# Altium schematic sheet MLRS_E28_TX.SchDoc
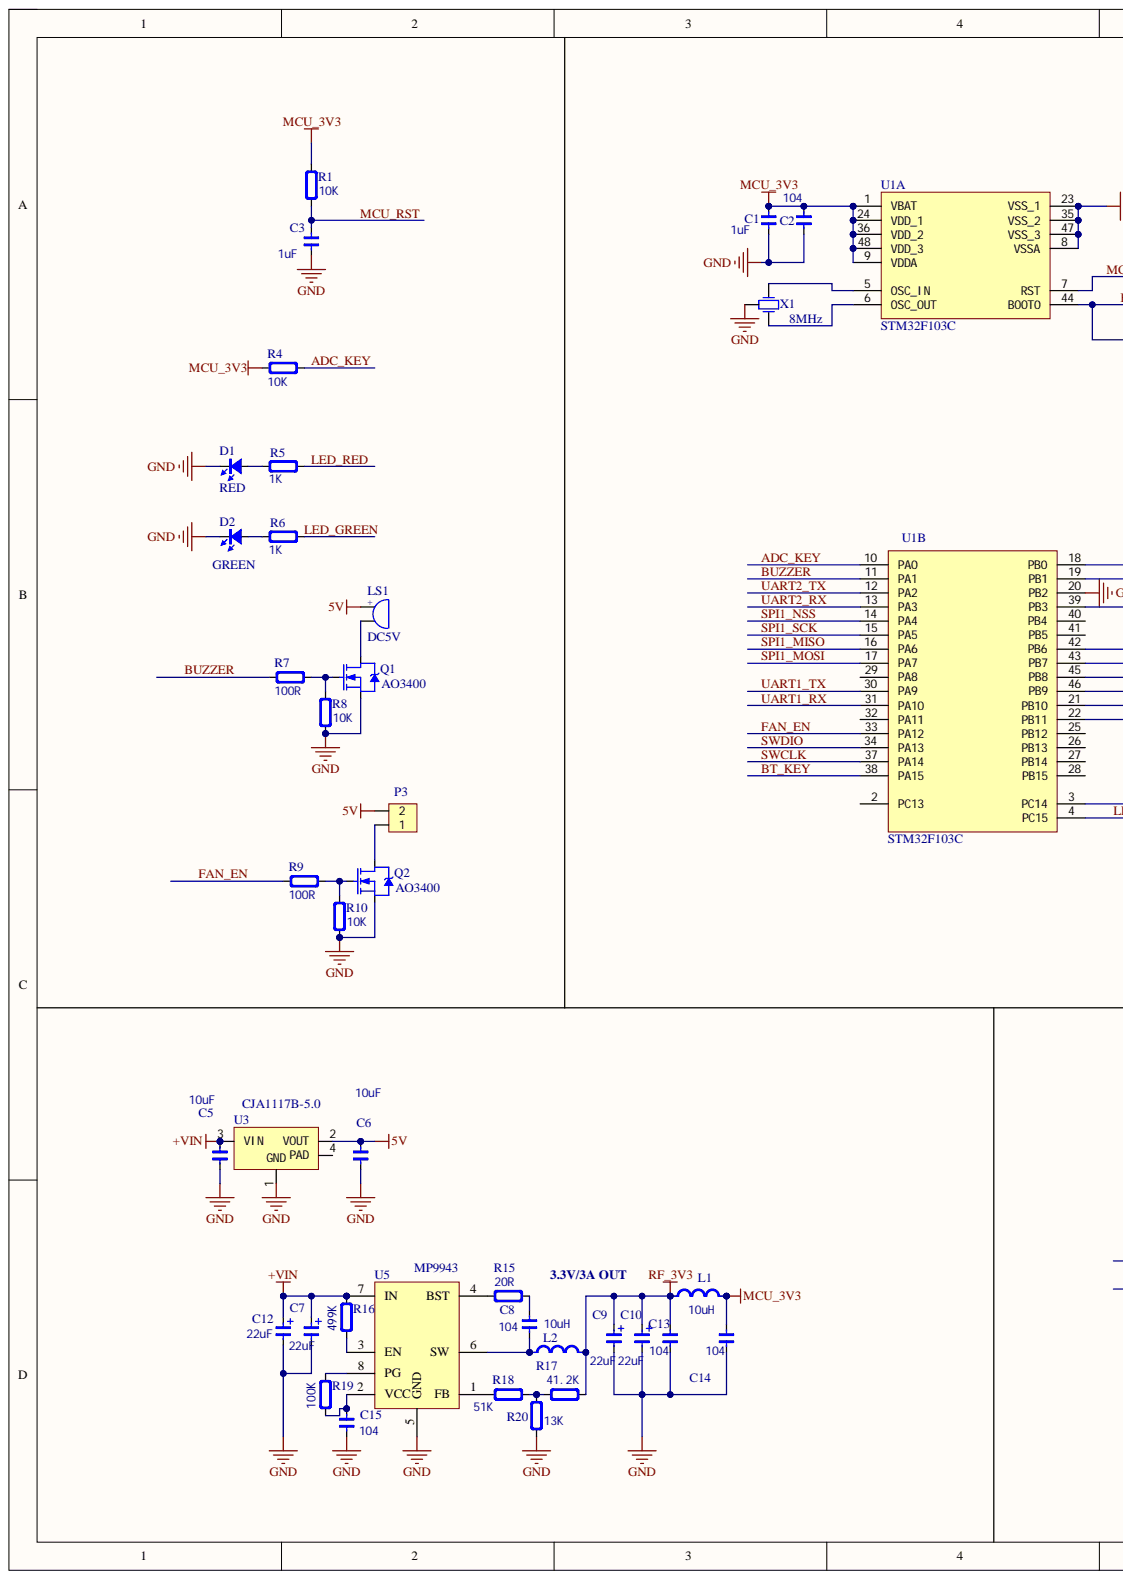
[diagram p0: MLRS_E28_TX.SchDoc, left]
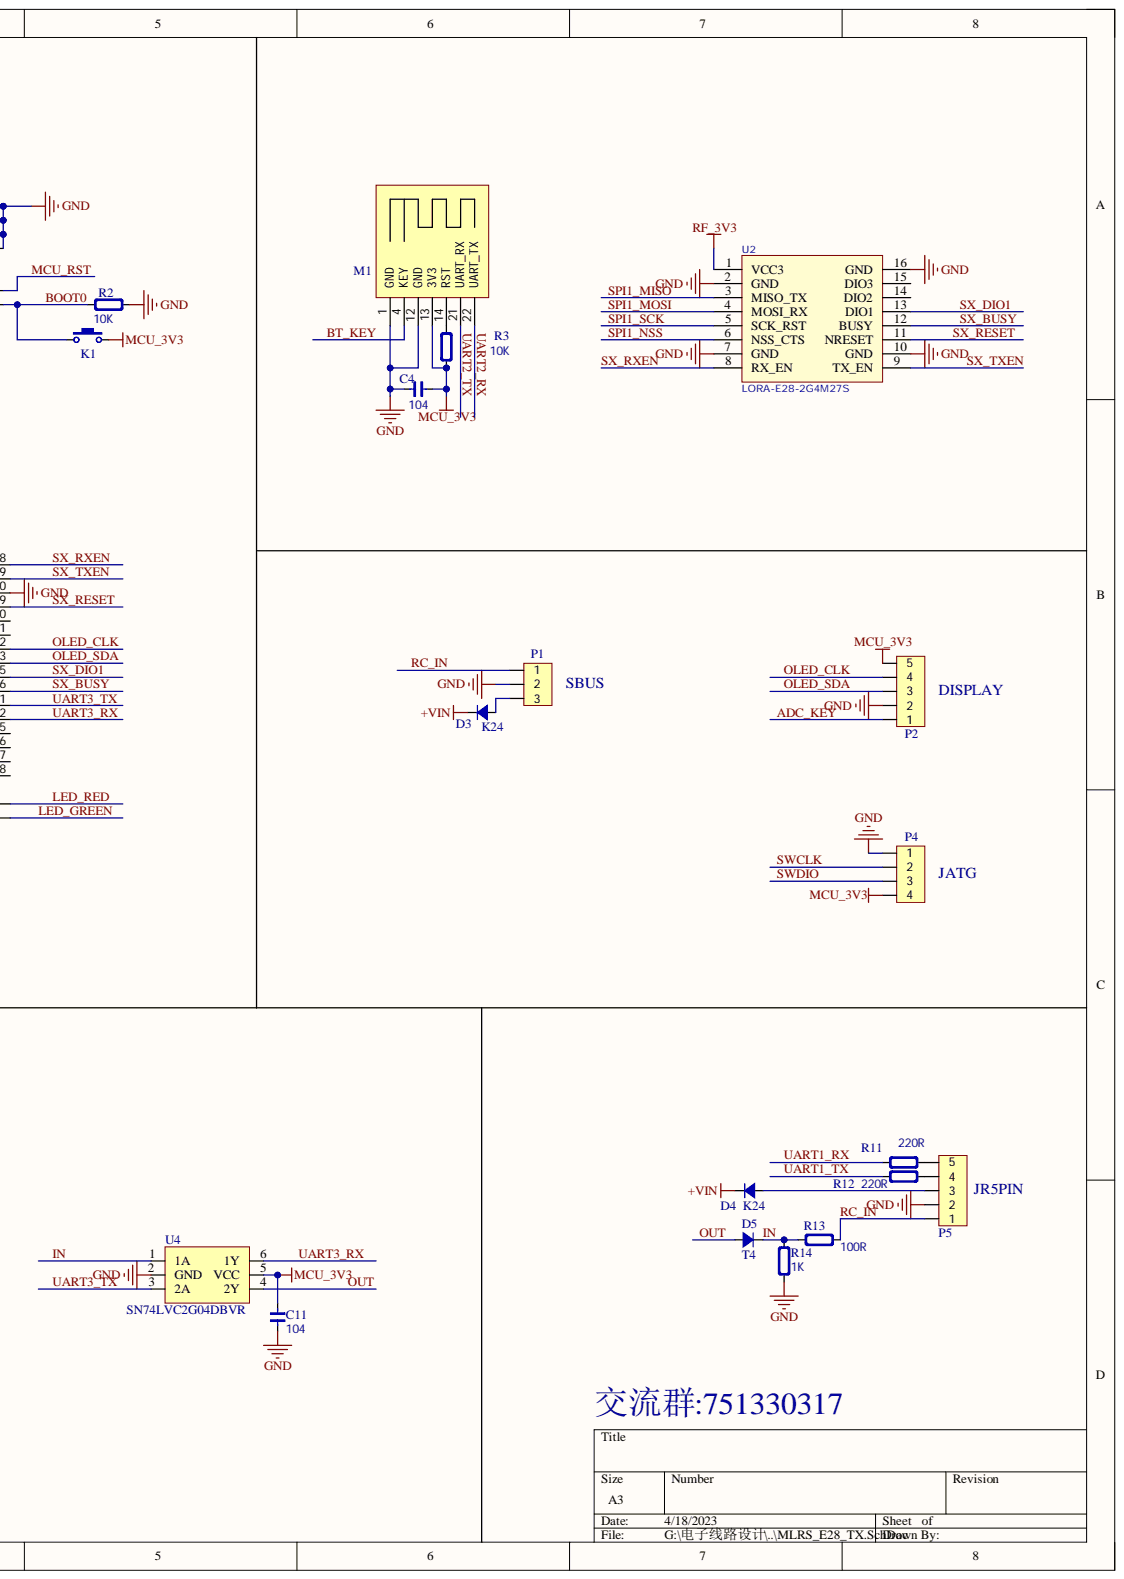
[diagram p1: MLRS_E28_TX.SchDoc, right]

## Parts
C1  1uF  [C0402S]
C2  104  [C0402S]
C3  1uF  [C0402S]
C4  104  [C0402S]
C5  10uF  [CAP_0603]
C6  10uF  [CAP_0603]
C7  22uF  [CAP_TAN_A1206]
C8  104  [C0402S]
C9  22uF  [CAP_TAN_A1206]
C10  22uF  [CAP_TAN_A1206]
C11  104  [C0402S]
C12  22uF  [CAP_TAN_A1206]
C13  104  [CAP_0603]
C14  104  [CAP_0603]
C15  104  [C0402S]
D1  RED  [LED_0603_RED]
D2  GREEN  [LED_0603_GREEN]
D3  K24  [SOD-123]
D4  K24  [SOD-123]
D5  T4  [SOD-323]
K1  TACT  [JUMP]  (value not drawn)
L1  10uH  [IND_0603]
L2  10uH  [NR4018]
LS1  DC5V  [BUZ-SMD_4P-L7.5-W7.5-P7.59-BR]
M1  SmartBLE  [BT04-E]  (value not drawn)
P1  GH1.25-3P  [GH1.25-WS-3P]  (value not drawn)
P2  HDR1x5  [MX 1.25-LI-5P]  (value not drawn)
P3  HDR1x2  [MX 1.25-LI-2P]  (value not drawn)
P4  HDR1x4  [JTAG_1.5_PAD]  (value not drawn)
P5  B2541W  [B2541BW-5P]  (value not drawn)
Q1  AO3400  [SOT23-3]
Q2  AO3400  [SOT23-3]
R1  10K  [R0402S]
R2  10K  [R0402S]
R3  10K  [R0402S]
R4  10K  [R0402S]
R5  1K  [R0402S]
R6  1K  [R0402S]
R7  100R  [R0402S]
R8  10K  [R0402S]
R9  100R  [R0402S]
R10  10K  [R0402S]
R11  220R  [R0402S]
R12  220R  [R0402S]
R13  100R  [R0402S]
R14  1K  [R0402S]
R15  20R  [R0402S]
R16  499K  [R0402S]
R17  41.2K  [R0402S]
R18  51K  [R0402S]
R19  100K  [R0402S]
R20  13K  [R0402S]
U1  STM32F103C  [LQFP_P0.5_48]  (parts A,B)
U2  LORA-E28-2G4M27S  [E28-2G4M2XS]
U3  CJA1117B-5.0  [SOT89-3]
U4  SN74LVC2G04DBVR  [SOT23-6]
U5  MP9943  [QFN-8_MP9943_MN]
X1  8MHz  [OSC-3213]

## Nets
5V: C6.2 LS1.1 P3.2 U3.2(VOUT)
+VIN: C5.2 C7.1 C12.1 D3.2 D4.2 R16.2 U3.3(VIN) U5.7(IN)
GND: C1.1 C2.1 C3.1 C4.1 C5.1 C6.1 C7.2 C9.2 C10.2 C11.1 C12.2 C13.1 C14.1 C15.1 D1.2 D2.2 M1.1(GND) M1.12(GND) P1.2 P2.2 P4.1 P5.2 Q1.2 Q2.2 R2.2 R8.1 R10.1 R14.2 R20.2 U1.8(VSSA) U1.20(PB2) U1.23(VSS_1) U1.35(VSS_2) U1.47(VSS_3) U2.2(GND) U2.7(GND) U2.10(GND) U2.16(GND) U3.1 U4.2(GND) U5.5(GND) X1.2
MCU_3V3: C1.2 C2.2 C4.2 C11.2 C14.2 K1.2 L1.2 M1.13(3V3) P2.5 P4.4 R1.2 R3.1 R4.2 U1.1(VBAT) U1.9(VDDA) U1.24(VDD_1) U1.36(VDD_2) U1.48(VDD_3) U4.5(VCC)
RF_3V3: C9.1 C10.1 C13.2 L1.1 L2.2 R17.1 U2.1(VCC3)
ADC_KEY: P2.1 R4.1 U1.10(PA0)
BOOT0: K1.1 R2.1 U1.44(BOOT0)
BT_KEY: M1.4(KEY) U1.38(PA15)
BUZZER: R7.2 U1.11(PA1)
FAN_EN: R9.2 U1.33(PA12)
IN: D5.2 R13.2 R14.1 U4.1(1A)
LED_GREEN: R6.1 U1.4(PC15)
LED_RED: R5.1 U1.3(PC14)
MCU_RST: C3.2 R1.1 U1.7(RST)
OLED_CLK: P2.4 U1.42(PB6)
OLED_SDA: P2.3 U1.43(PB7)
OUT: D5.1 U4.4(2Y)
RC_IN: P1.1 P5.1 R13.1
SPI1_MISO: U1.16(PA6) U2.3(MISO_TX)
SPI1_MOSI: U1.17(PA7) U2.4(MOSI_RX)
SPI1_NSS: U1.14(PA4) U2.6(NSS_CTS)
SPI1_SCK: U1.15(PA5) U2.5(SCK_RST)
SWCLK: P4.2 U1.37(PA14)
SWDIO: P4.3 U1.34(PA13)
SX_BUSY: U1.46(PB9) U2.12(BUSY)
SX_DIO1: U1.45(PB8) U2.13(DIO1)
SX_RESET: U1.39(PB3) U2.11(NRESET)
SX_RXEN: U1.18(PB0) U2.8(RX_EN)
SX_TXEN: U1.19(PB1) U2.9(TX_EN)
UART1_RX: R11.2 U1.31(PA10)
UART1_TX: R12.2 U1.30(PA9)
UART2_RX: M1.22(UART_TX) U1.13(PA3)
UART2_TX: M1.21(UART_RX) U1.12(PA2)
UART3_RX: U1.22(PB11) U4.6(1Y)
UART3_TX: U1.21(PB10) U4.3(2A)
NetC8_1: C8.1 L2.1 U5.6(SW)
NetC8_2: C8.2 R15.1
NetC15_2: C15.2 R19.1 U5.2(VCC)
NetD1_1: D1.1 R5.2
NetD2_1: D2.1 R6.2
NetD3_1: D3.1 P1.3
NetD4_1: D4.1 P5.3
NetLS1_2: LS1.2 Q1.3
NetM1_14: M1.14(RST) R3.2
NetP3_1: P3.1 Q2.3
NetP5_4: P5.4 R12.1
NetP5_5: P5.5 R11.1
NetQ1_1: Q1.1 R7.1 R8.2
NetQ2_1: Q2.1 R9.1 R10.2
NetR15_2: R15.2 U5.4(BST)
NetR16_1: R16.1 U5.3(EN)
NetR17_2: R17.2 R18.2 R20.1
NetR18_1: R18.1 U5.1(FB)
NetR19_2: R19.2 U5.8(PG)
NetU1_5: U1.5(OSC_IN) X1.3
NetU1_6: U1.6(OSC_OUT) X1.1

Not connected: U1 2(PC13) 25(PB12) 26(PB13) 27(PB14) 28(PB15) 29(PA8) 32(PA11) 40(PB4) 41(PB5); U2 14(DIO2) 15(DIO3); U3 4(GND_PAD)

## Library data of the parts
C1-C6 C8 C11 C13-C15 = Cap_0603: Capacitor; Note: ±10%; Specification: X7R, ±10%, 16V; Type: 0603; Value: ?F; Component Kind: Standard; Library Name: SCH_Passive.SchLib
C7 C9 C10 C12 = Cap_Tan_1210: Capacitor Tantalum; Note: ±10%; Specification: X7R, ±10%, 16V; Type: 1210; Value: ?F; Component Kind: Standard; Library Name: SCH_Passive.SchLib
D1 = LED_0603: LED; Type: 0603; Component Kind: Standard; Library Name: SCH_Active.SchLib; Value: RED
D2 = LED_0603: LED; Type: 0603; Value: RED; Component Kind: Standard; Library Name: SCH_Active.SchLib
D3-D5 = Diode_SOD123: Diode; Type: SOD123; Value: S4; Component Kind: Standard; Library Name: SCH_Active.SchLib
K1 = ButtonTACT_180_3x4x2: Button; value: 3x4mm; Component Kind: Standard; Library Name: SCH_Switch.SchLib; type: TACT 2P
L1 L2 = Ind_0603: Inductor; Note: ±5%; Specification: High frequency chip inductor ±5%; Type: 0603; Component Kind: Standard; Library Name: SCH_Passive.SchLib; Value: 10uH
LS1 = BEEP: 蜂鸣器; 版权归属: 源创客; BOM名称: 蜂鸣器; Component Kind: Standard; Library Name: 15.1 - 未分类器件.SchLib; 学习资源: www.ooocke.com
M1 = BT04-E: Module; Component Kind: Standard; Library Name: SCH_Module.SchLib
P1 = HDR1x3_2.54: Header; Value: 2.54; Component Kind: Standard; Library Name: SCH_ConnectorHDR.SchLib; Type: DIP 1x3
P2 P5 = HDR1x5_2.54: Header; Value: 2.54; Component Kind: Standard; Library Name: SCH_ConnectorHDR.SchLib; Type: DIP 1x5
P3 = HDR1x2_2.54: Header; Value: 2.54; Component Kind: Standard; Library Name: SCH_ConnectorHDR.SchLib; Type: DIP 1x2
P4 = HDR1x4_2.54: Header; Value: 2.54; Component Kind: Standard; Library Name: SCH_ConnectorHDR.SchLib; Type: DIP 1x4
Q1 Q2 = MOSFET_N_SOT23: MOSFET; Note: NMOS; Value: NAME; Component Kind: Standard; Library Name: SCH_Active.SchLib; Type: SOT23-3
R1-R4 R7-R13 R15-R20 = Res_0402: Resistor; Note: ±1%; Value: 1K; Component Kind: Standard; Library Name: SCH_Passive.SchLib; Specification: ±1%; Type: 0402
R5 R6 R14 = Res_0402: Resistor; Note: ±1%; Component Kind: Standard; Library Name: SCH_Passive.SchLib; Specification: ±1%; Type: 0402; Value: 1K
U1 = STM32F103C: MCU ST; Manufacturer: STMicroelectronics; Note: CortexM3; Component Kind: Standard; Library Name: SCH_Microcontroller.SchLib; Type: LQFP48 P0.5
U3 = LDO1117: Power; Component Kind: Standard; Library Name: SCH_Power.SchLib; Type: SOT223-3
X1 = XTAL_3213_3P: Crystal Oscillator; Specification: ±10ppm; Value: ?MHz; Component Kind: Standard; Library Name: SCH_Oscillator.SchLib; Type: XTAL5032_2P
U2 = LORA-E28-2G4M27S: Component Kind: Standard; Library Name: Schlib.SchLib
U4 = SN74LVC2G04DBVR: Component Kind: Standard; Library Name: Schlib.SchLib
U5 = MP9943: Component Kind: Standard; Library Name: Schlib.SchLib
